# Altium schematic sheet BatterySentinel-N2K-Orion-R5-Logic.SchDoc(BatterySentinel-N2K-Orion-R5-Logic)
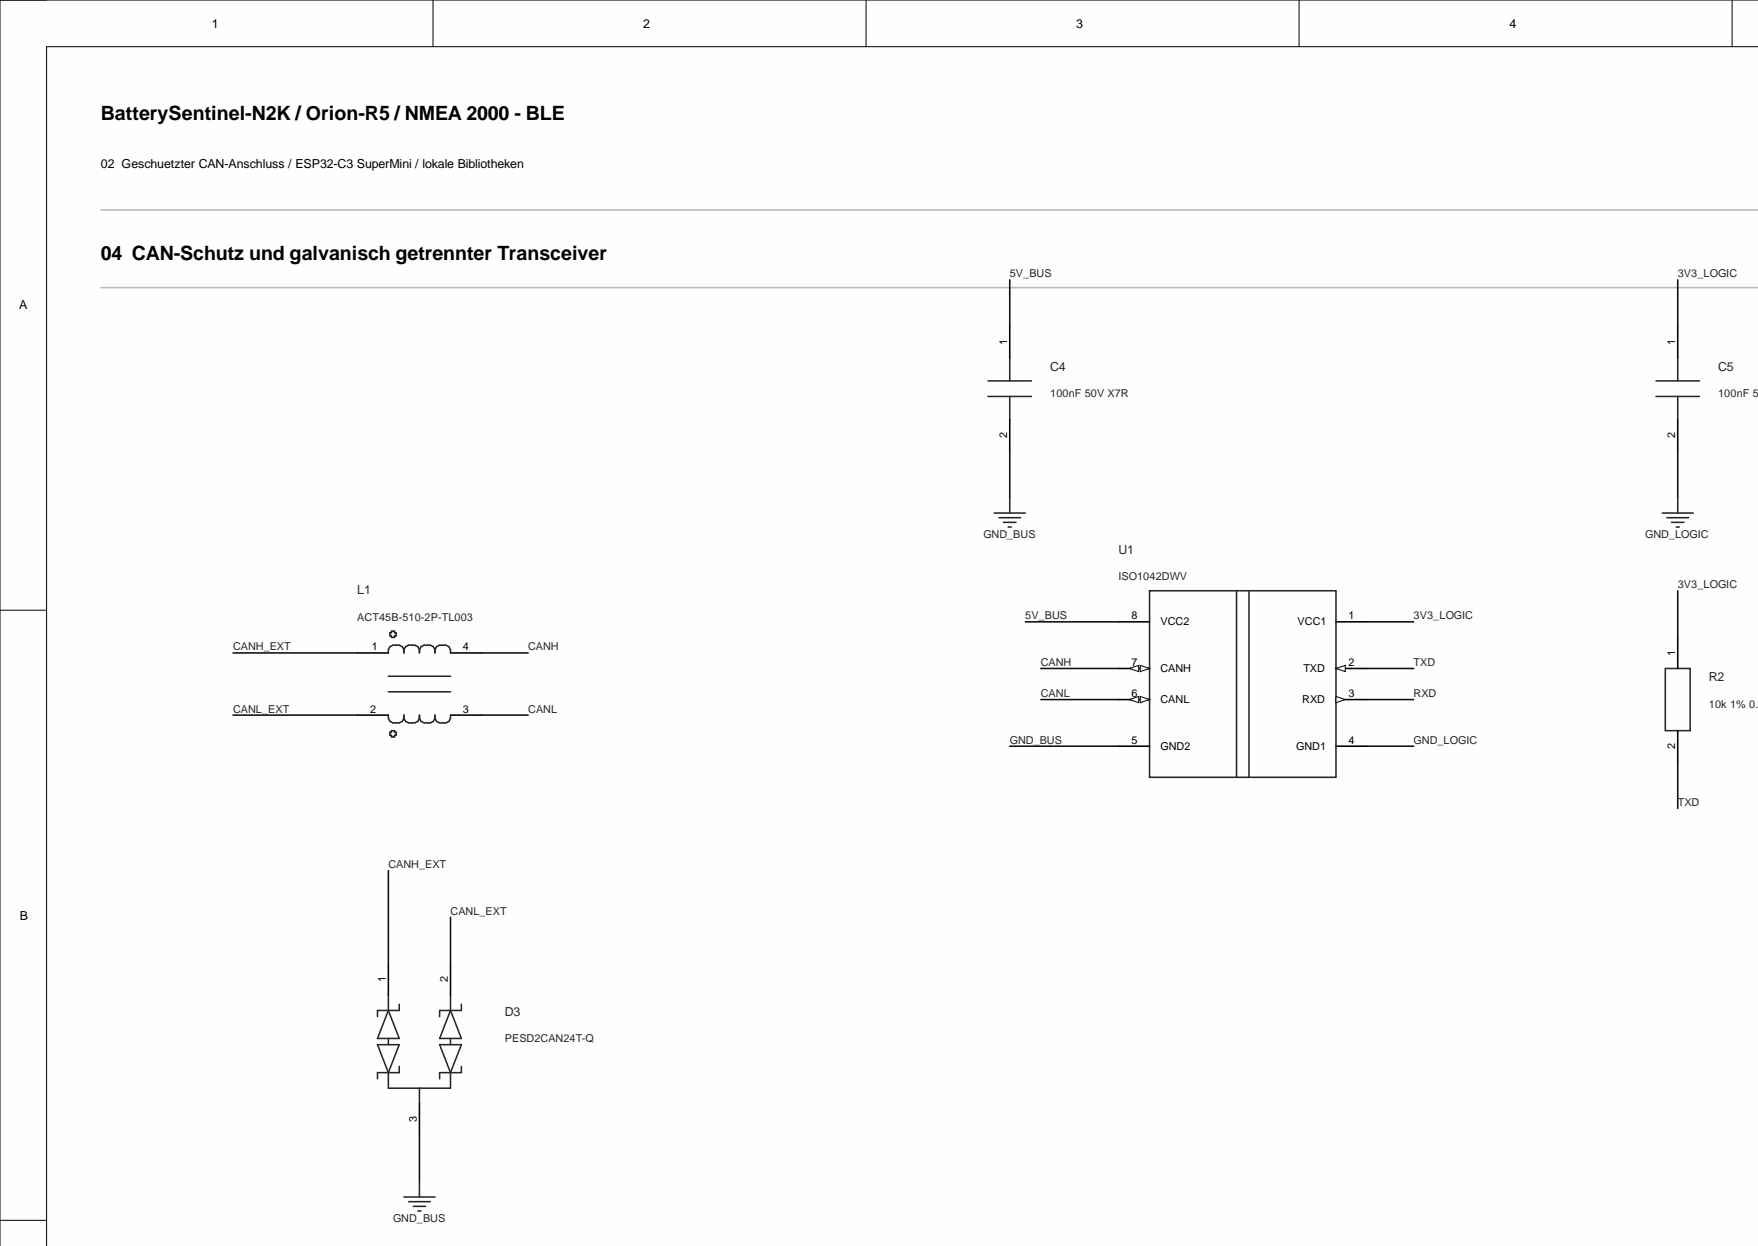
[diagram p0: BatterySentinel-N2K-Orion-R5-Logic.SchDoc, top left]
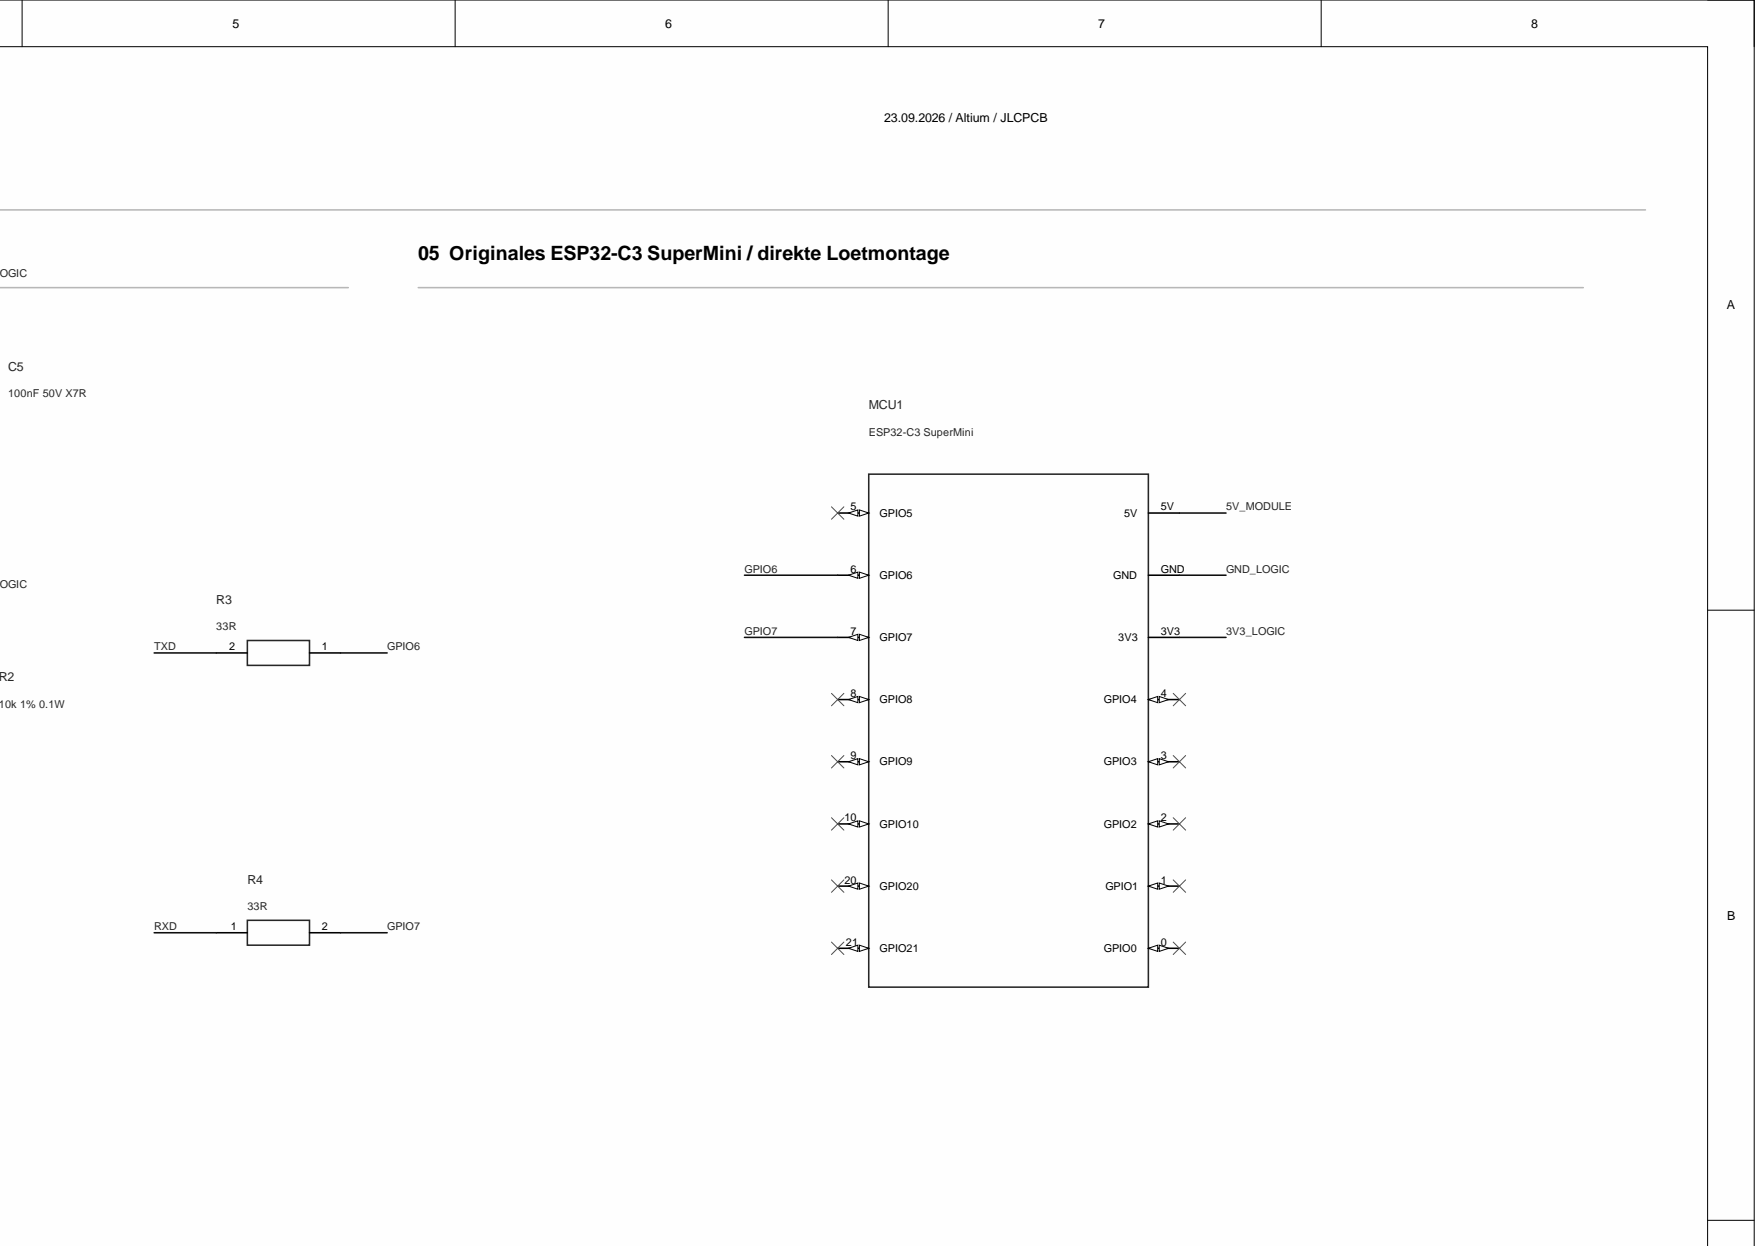
[diagram p1: BatterySentinel-N2K-Orion-R5-Logic.SchDoc, top right]
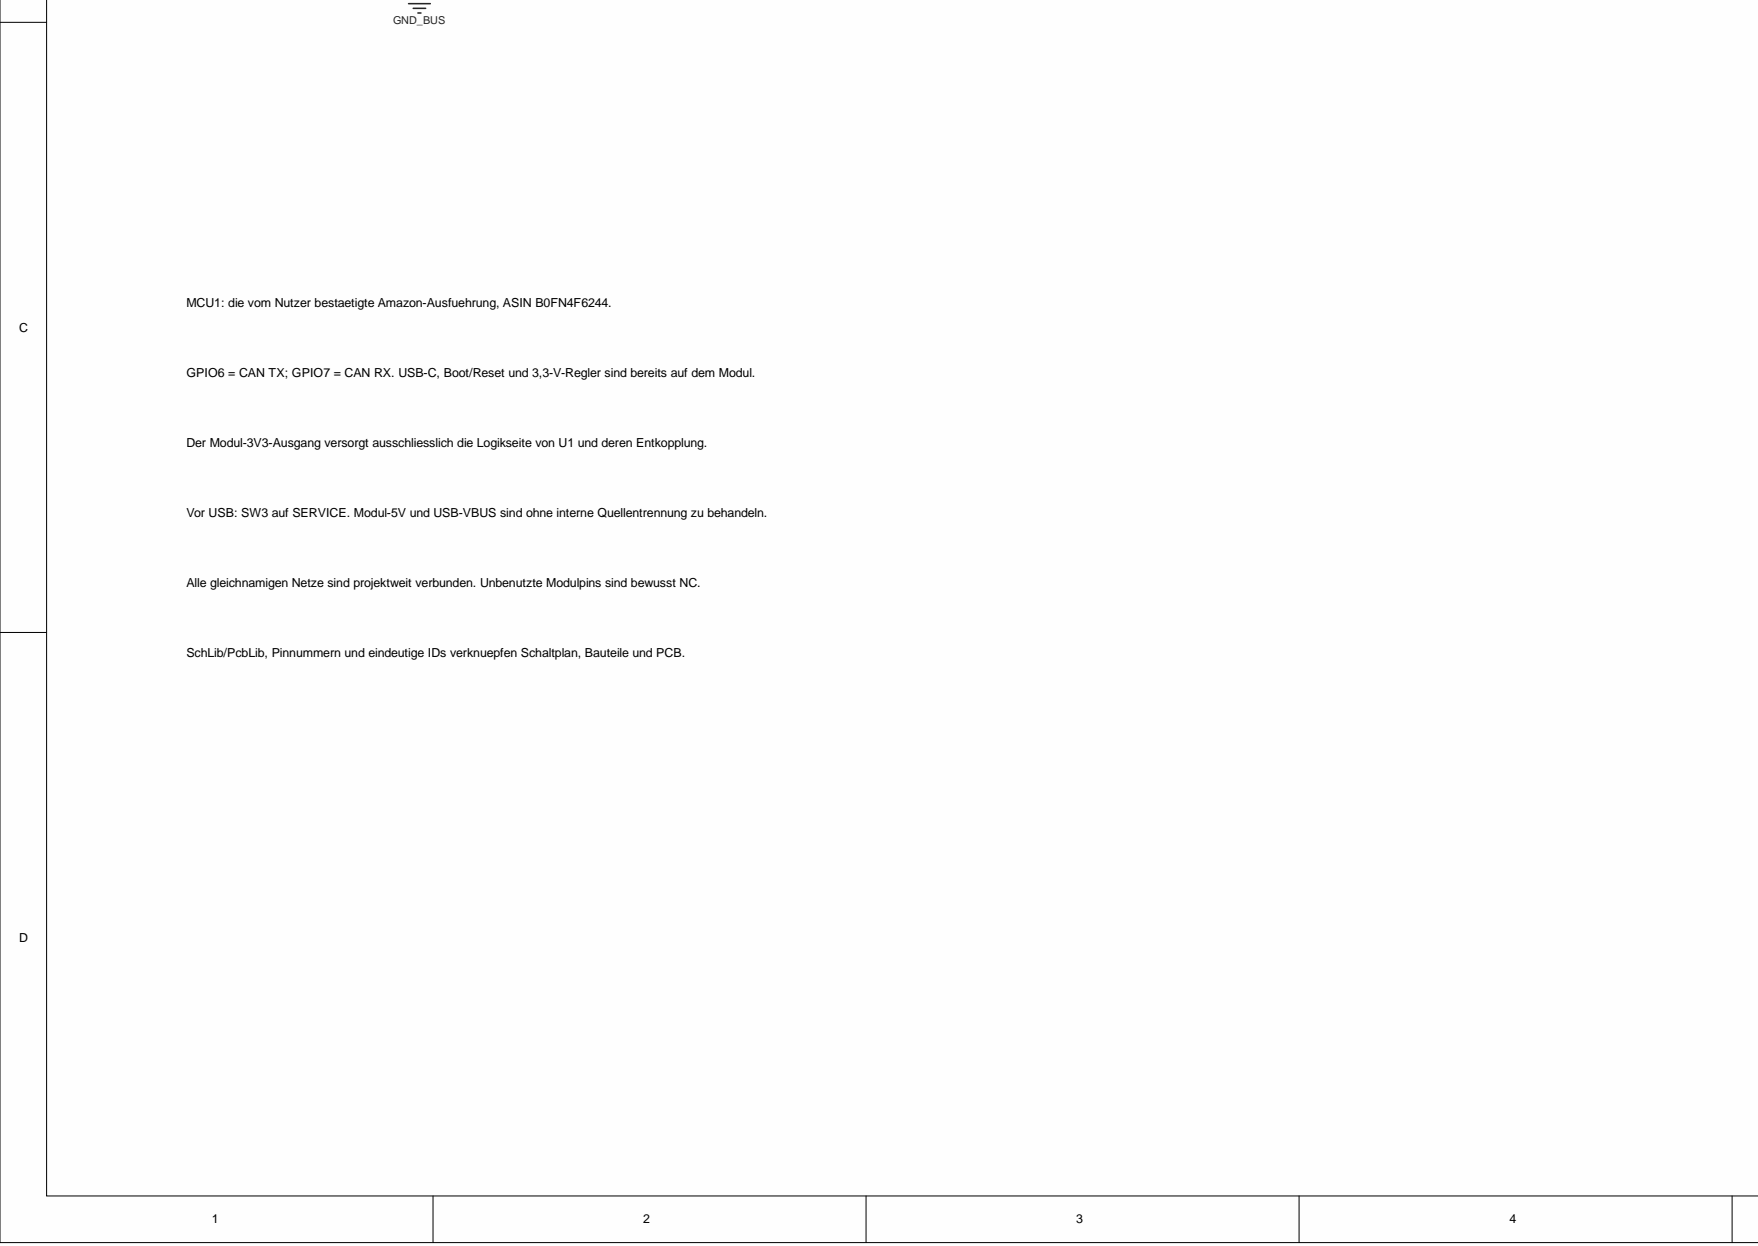
[diagram p2: BatterySentinel-N2K-Orion-R5-Logic.SchDoc, bottom left]
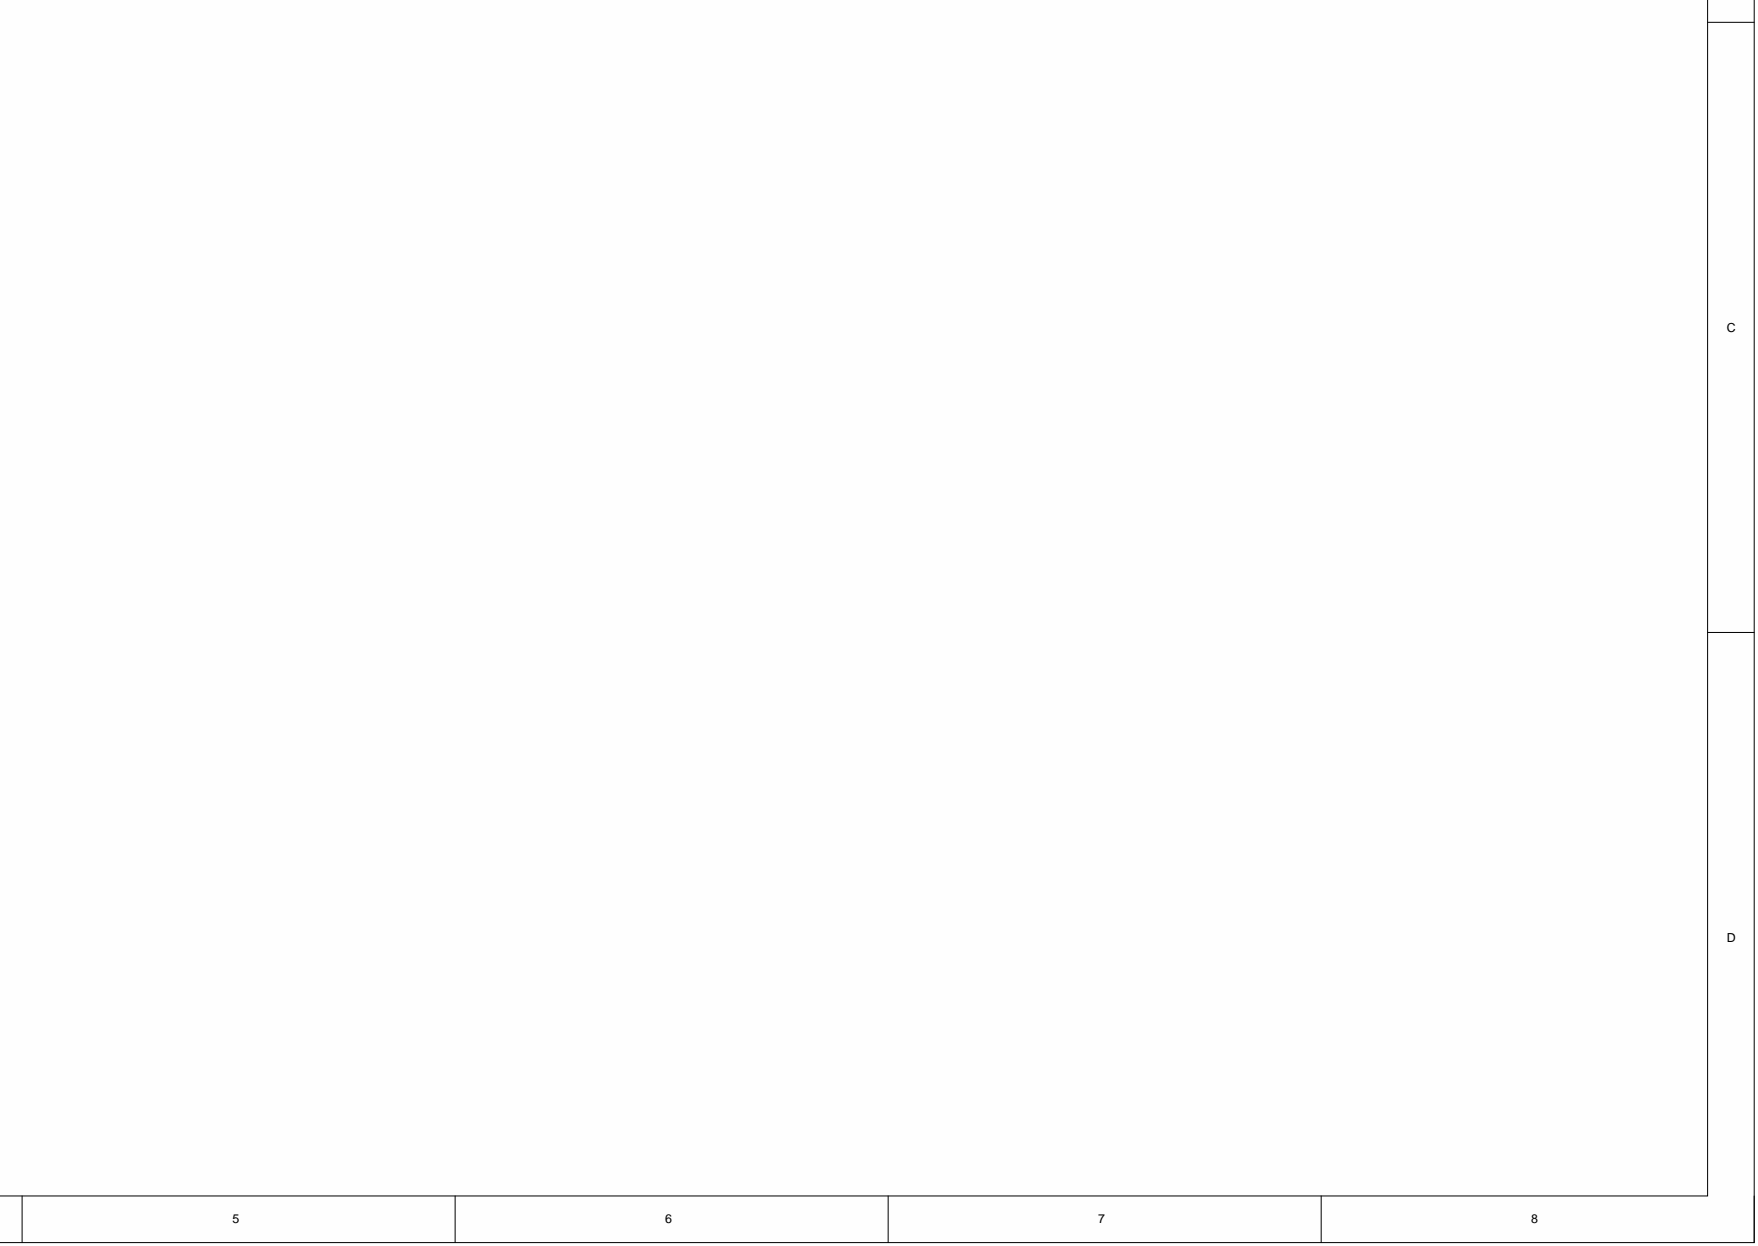
[diagram p3: BatterySentinel-N2K-Orion-R5-Logic.SchDoc, bottom right]

## Parts
C4  100nF 50V X7R  [C0603]
C5  100nF 50V X7R  [C0603]
D3  PESD2CAN24T-Q  [SOT23_NEXPERIA_REFLOW]
L1  ACT45B-510-2P-TL003  [ACT45B]
MCU1  ESP32-C3 SuperMini  [SUPERC3_B0FN4F6244_CAST16_SMD]
R2  10k 1% 0.1W  [R0603]
R3  33R  [R0603]
R4  33R  [R0603]
U1  ISO1042DWV  [SOIC8_DWV]

## Nets
3V3_LOGIC: C5.1 MCU1.3V3 R2.1 U1.1(VCC1)
5V_BUS: C4.1 U1.8(VCC2)
5V_MODULE: MCU1.5V
CANH: L1.4 U1.7(CANH)
CANH_EXT: D3.1 L1.1
CANL: L1.3 U1.6(CANL)
CANL_EXT: D3.2 L1.2
GND_BUS: C4.2 D3.3 U1.5(GND2)
GND_LOGIC: C5.2 MCU1.GND U1.4(GND1)
GPIO6: MCU1.6(GPIO6) R3.1
GPIO7: MCU1.7(GPIO7) R4.2
RXD: R4.1 U1.3(RXD)
TXD: R2.2 R3.2 U1.2(TXD)

Not connected: MCU1 0(GPIO0) 1(GPIO1) 2(GPIO2) 3(GPIO3) 4(GPIO4) 5(GPIO5) 8(GPIO8) 9(GPIO9) 10(GPIO10) 20(GPIO20) 21(GPIO21)

## Library data of the parts
C4 = R5_C4_100nF: 100nF 16V X7R - generic specification; manufacturer/MPN not selected; Manufacturer: YAGEO; Manufacturer Part Number: CC0603KRX7R9BB104; ReviewStatus: R5 placement with enclosure interface; Component Kind: Standard; Datasheet: https://www.lcsc.com/datasheet/lcsc_datasheet_2211101700_YAGEO-CC0603KRX7R9BB104_C14663.pdf; Footprint Status: R5 placement with enclosure interface; MPN: CC0603KRX7R9BB104; Supplier 1: LCSC; Supplier Part Number 1: C14663; Library Name: Orion-Bridge.SchLib
C5 = R5_C5_100nF: 100nF 16V X7R - generic specification; manufacturer/MPN not selected; Manufacturer: YAGEO; Manufacturer Part Number: CC0603KRX7R9BB104; ReviewStatus: R5 placement with enclosure interface; Component Kind: Standard; Datasheet: https://www.lcsc.com/datasheet/lcsc_datasheet_2211101700_YAGEO-CC0603KRX7R9BB104_C14663.pdf; Footprint Status: R5 placement with enclosure interface; MPN: CC0603KRX7R9BB104; Supplier 1: LCSC; Supplier Part Number 1: C14663; Library Name: Orion-Bridge.SchLib
D3 = R5_D3_PESD2CAN24T_Q: Manufacturer: Nexperia; Manufacturer Part Number: PESD2CAN24T-QR; ReviewStatus: R5 placement with enclosure interface; Component Kind: Standard; Datasheet: https://assets.nexperia.com/documents/data-sheet/PESD2CAN24T-Q.pdf; Description: PESD2CAN24T-Q; Footprint Status: R5 placement with enclosure interface; MPN: PESD2CAN24T-QR; Supplier 1: LCSC; Supplier Part Number 1: C28933048; Library Name: Orion-Bridge.SchLib
L1 = R5_L1_ACT45B_510_2P_TL003: Manufacturer: TDK; ReviewStatus: R5 placement with enclosure interface; Component Kind: Standard; Datasheet: https://www.lcsc.com/datasheet/lcsc_datasheet_1811151430_TDK-ACT45B-510-2P-TL003_C76584.pdf; Description: ACT45B-510-2P-TL003; Footprint Status: R5 placement with enclosure interface; Manufacturer Part Number: ACT45B-510-2P-TL003; MPN: ACT45B-510-2P-TL003; Supplier 1: LCSC; Supplier Part Number 1: C76584; Library Name: Orion-Bridge.SchLib
MCU1 = R5_MCU1_ESP32_C3: User-selected C3 SuperMini; original onboard USB/BOOT/RESET; direct castellated solder mounting; Manufacturer: Meshnology (seller brand; OEM undisclosed); Manufacturer Part Number: ESP32-C3 SuperMini / ASIN B0FN4F6244; ReviewStatus: R5 placement with enclosure interface; Component Kind: Standard; Datasheet: https://www.amazon.de/4PCS-Supermini-ESP32-C3-Entwicklungsboard/dp/B0FN4F6244; Footprint Status: R5 placement with enclosure interface; MPN: ESP32-C3 SuperMini / ASIN B0FN4F6244; Library Name: Orion-Bridge.SchLib
R2 = R5_R2_10k: 10k - generic specification; manufacturer/MPN not selected; Manufacturer: UNI-ROYAL(Uniroyal Elec); Manufacturer Part Number: 0603WAF1002T5E; ReviewStatus: R5 placement with enclosure interface; Component Kind: Standard; Datasheet: https://www.lcsc.com/datasheet/lcsc_datasheet_2206010045_UNI-ROYAL-Uniroyal-Elec-0603WAF1002T5E_C25804.pdf; Footprint Status: R5 placement with enclosure interface; MPN: 0603WAF1002T5E; Supplier 1: LCSC; Supplier Part Number 1: C25804; Library Name: Orion-Bridge.SchLib
R3 = R5_R3_33R: 33R - generic specification; manufacturer/MPN not selected; Manufacturer: UNI-ROYAL(Uniroyal Elec); Manufacturer Part Number: 0603WAF330JT5E; ReviewStatus: R5 placement with enclosure interface; Role: R_TX; Component Kind: Standard; Datasheet: https://www.lcsc.com/datasheet/lcsc_datasheet_2206010116_UNI-ROYAL-Uniroyal-Elec-0603WAF330JT5E_C23140.pdf; Footprint Status: R5 placement with enclosure interface; MPN: 0603WAF330JT5E; Supplier 1: LCSC; Supplier Part Number 1: C23140; Library Name: Orion-Bridge.SchLib
R4 = R5_R4_33R: 33R - generic specification; manufacturer/MPN not selected; Manufacturer: UNI-ROYAL(Uniroyal Elec); Manufacturer Part Number: 0603WAF330JT5E; ReviewStatus: R5 placement with enclosure interface; Role: R_RX; Component Kind: Standard; Datasheet: https://www.lcsc.com/datasheet/lcsc_datasheet_2206010116_UNI-ROYAL-Uniroyal-Elec-0603WAF330JT5E_C23140.pdf; Footprint Status: R5 placement with enclosure interface; MPN: 0603WAF330JT5E; Supplier 1: LCSC; Supplier Part Number 1: C23140; Library Name: Orion-Bridge.SchLib
U1 = R5_U1_ISO1042DWV: Manufacturer: Texas Instruments; Manufacturer Part Number: ISO1042DWVR; ReviewStatus: R5 placement with enclosure interface; Component Kind: Standard; Datasheet: https://datasheet.lcsc.com/lcsc/2302212001_Texas-Instruments-ISO1042DWVR_C2671714.pdf; Description: ISO1042DWV; Footprint Status: R5 placement with enclosure interface; MPN: ISO1042DWVR; Supplier 1: LCSC; Supplier Part Number 1: C2671714; Library Name: Orion-Bridge.SchLib
